# Altium schematic sheet 原理图1.~(1).SchDoc
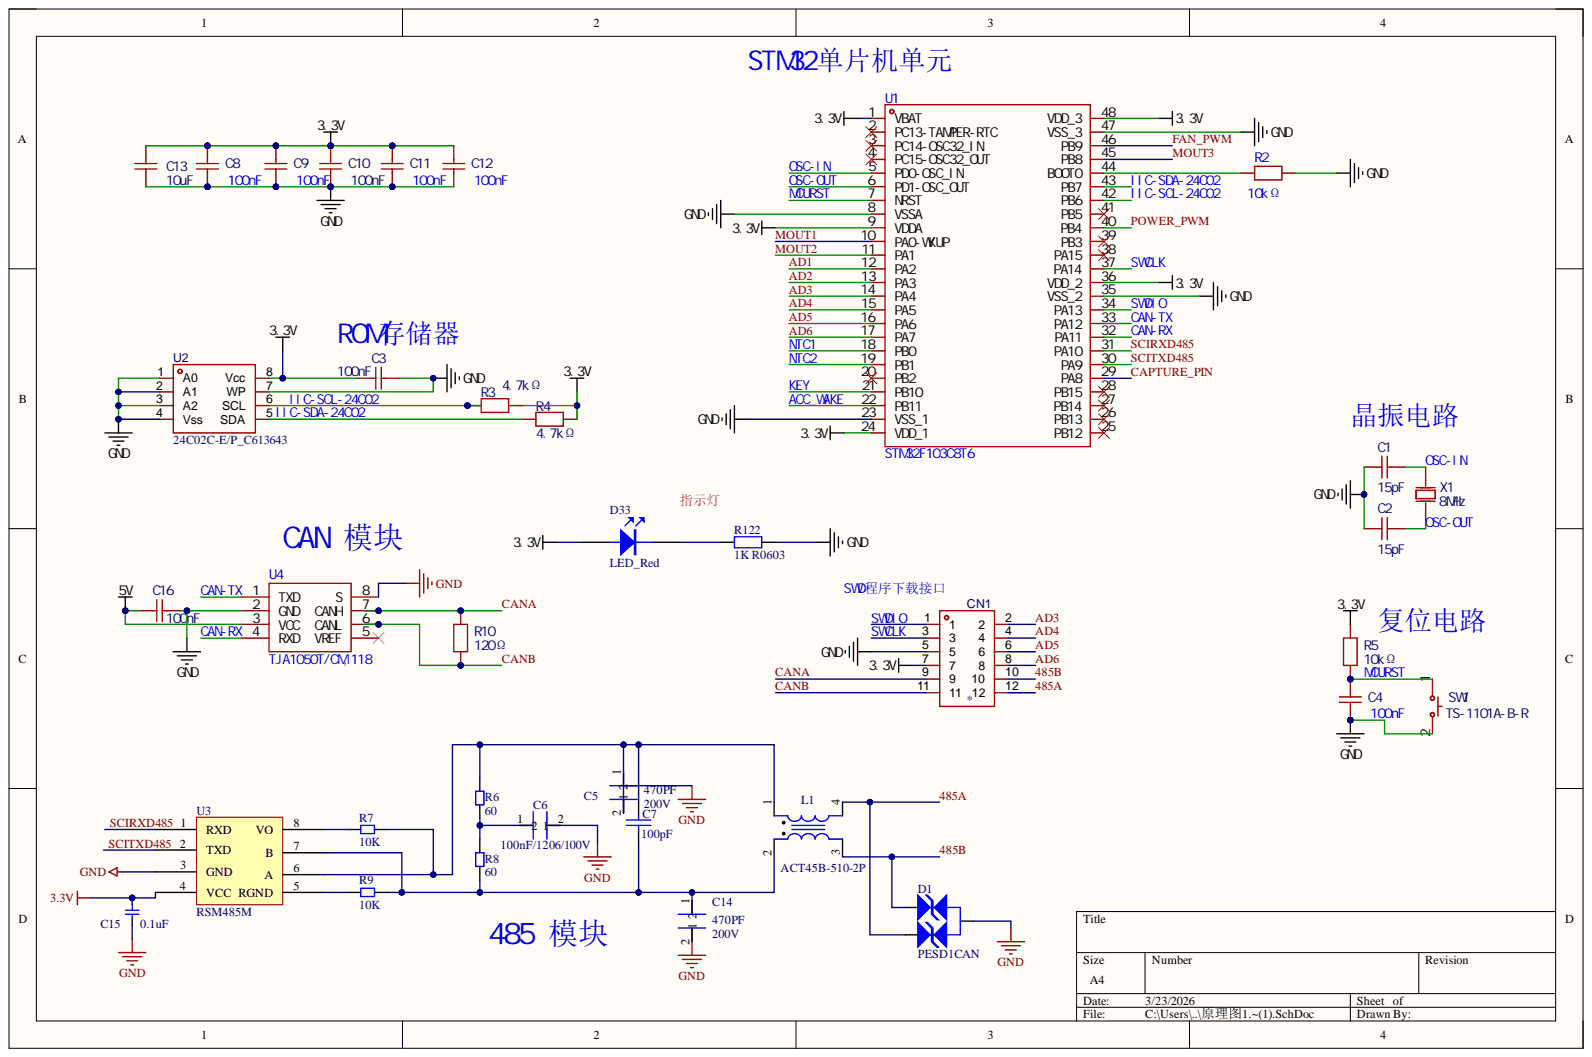
[diagram p0: 原理图1.~(1).SchDoc]

## Parts
C1  15pF  [C0603]
C2  15pF  [C0603]
C3  100nF  [C0603]
C4  100nF  [C0603]
C5  value 470PF  [C1206]  (hidden comment: 470PF, 200V, 5%,)
C6  100nF/1206/100V  [C1206]
C7  100pF  [C0805]
C8  100nF  [C0603]
C9  100nF  [C0603]
C10  100nF  [C0603]
C11  100nF  [C0603]
C12  100nF  [C0603]
C13  10uF  [C0805]
C14  value 470PF  Voltage Rating: 200V  [C1206]  (hidden comment: 470PF, 200V, 5%,)
C15  0.1uF  [C0603]
C16  100nF  [C0603]
CN1  (no value)  [IDC-TH_X9555WV-2X06-PTV01]
D1  PESD1CAN  [SOT-23-D]
D33  LED_Red  [LED0805]
L1  ACT45B-510-2P  [LS-ACT45B-510-2P]
R2  10kΩ  [R0603]
R3  4.7kΩ  [R0603]
R4  4.7kΩ  [R0603]
R5  10kΩ  [R0603]
R6  60  [R0805]
R7  10K  [R0805]
R8  60  [R0805]
R9  10K  [R0805]
R10  120Ω  [R0603]
R122  1K R0603  [R0603]
SW1  TS-1101A-B-R  [SW-SMD_L6.00-W3.60-LS6.0]
U1  STM32F103C8T6  [LQFP-48_L7.0-W7.0-P0.50-LS9.0-BL]
U2  24C02C-E/P_C613643  [PDIP-8_L9.3-W6.4-P2.54-LS7.6-BL]
U3  RSM485M  [RSM485M]
U4  TJA1050T/CM,118  [SOIC-8_L5.0-W4.0-P1.27-LS6.0-BL]
X1  8MHz  [HC-49S_L11.4-W4.8]

## Nets
3.3V: C3.2 C8.2 C9.2 C10.2 C11.2 C12.2 C13.2 C15.1 CN1.7 D33.1 R3.1 R4.1 R5.2 U1.1(VBAT) U1.9(VDDA) U1.24(VDD_1) U1.36(VDD_2) U1.48(VDD_3) U2.8(Vcc) U3.4(VCC)
5V: C16.2 U4.3(VCC)
GND: C1.1 C2.1 C3.1 C4.1 C5.2 C6.2 C8.1 C9.1 C10.1 C11.1 C12.1 C13.1 C14.2 C15.2 C16.1 CN1.5 D1.3 R2.2 R122.2 SW1.2 U1.8(VSSA) U1.23(VSS_1) U1.35(VSS_2) U1.47(VSS_3) U2.1(A0) U2.2(A1) U2.3(A2) U2.4(Vss) U2.7(WP) U3.3(GND) U4.2(GND) U4.8(S)
485A: CN1.12 D1.2 L1.4
485B: CN1.10 D1.1 L1.3
ACC_WAKE: U1.22(PB11)
AD1: U1.12(PA2)
AD2: U1.13(PA3)
AD3: CN1.2 U1.14(PA4)
AD4: CN1.4 U1.15(PA5)
AD5: CN1.6 U1.16(PA6)
AD6: CN1.8 U1.17(PA7)
CAN-RX: U1.32(PA11) U4.4(RXD)
CAN-TX: U1.33(PA12) U4.1(TXD)
CANA: CN1.9 R10.2 U4.7(CANH)
CANB: CN1.11 R10.1 U4.6(CANL)
CAPTURE_PIN: U1.29(PA8)
FAN_PWM: U1.46(PB9)
IIC-SCL-24C02: R3.2 U1.42(PB6) U2.6(SCL)
IIC-SDA-24C02: R4.2 U1.43(PB7) U2.5(SDA)
KEY: U1.21(PB10)
MCURST: C4.2 R5.1 SW1.1 U1.7(NRST)
MOUT1: U1.10(PA0-WKUP)
MOUT2: U1.11(PA1)
MOUT3: U1.45(PB8)
NTC1: U1.18(PB0)
NTC2: U1.19(PB1)
OSC-IN: C1.2 U1.5(PD0-OSC_IN) X1.1
OSC-OUT: C2.2 U1.6(PD1-OSC_OUT) X1.2
POWER_PWM: U1.40(PB4)
SCIRXD485: U1.31(PA10) U3.1(RXD)
SCITXD485: U1.30(PA9) U3.2(TXD)
SWCLK: CN1.3 U1.37(PA14)
SWDIO: CN1.1 U1.34(PA13)
NetC5_1: C5.1 C7.1 L1.1 R6.2 R7.1 U3.6(A)
NetC6_1: C6.1 R6.1 R8.2
NetC7_2: C7.2 C14.1 L1.2 R8.1 R9.1 U3.7(B)
NetD33_2: D33.2 R122.1
NetR2_1: R2.1 U1.44(BOOT0)
NetR7_2: R7.2 U3.8(VO)
NetR9_2: R9.2 U3.5(RGND)

Not connected: U1 2(PC13-TAMPER-RTC) 3(PC14-OSC32_IN) 4(PC15-OSC32_OUT) 20(PB2) 25(PB12) 26(PB13) 27(PB14) 28(PB15) 38(PA15) 39(PB3) 41(PB5); U4 5(VREF)

## Library data of the parts
C1 C2 = GRM21BR60J107ME15L: Manufacturer: muRata(村田); Add into BOM: yes; Temperature Coefficient: X5R; Tolerance: ±20%; Voltage Rated: 6.3V; Component Kind: Standard; Convert to PCB: yes; Datasheet: https://atta.szlcsc.com/upload/public/pdf/source/20171113/C141660_15105613320671115822.pdf; Device: GRM21BR60J107ME15L; JLCPCB Part Class: 扩展库; LCSC Part Name: 100uF ±20% 6.3V; Manufacturer Part: GRM21BR60J107ME15L; PCB3D: C0805_L2.0-W1.3-H1.3; Supplier: LCSC; Supplier Footprint: 0805; Supplier Part: C141660; Symbol: GRM21BR60J107ME15L; Value: 15pF
C3 C4 C8-C12 C16 = CL21B104KBCNNNC: Manufacturer: SAMSUNG(三星); Add into BOM: yes; Temperature Coefficient: X7R; Tolerance: ±10%; Voltage Rated: 50V; Component Kind: Standard; Convert to PCB: yes; Datasheet: https://atta.szlcsc.com/upload/public/pdf/source/20160103/1457707693305.pdf; Device: CL21B104KBCNNNC; JLCPCB Part Class: 扩展库; LCSC Part Name: 100nF ±10% 50V; Manufacturer Part: CL21B104KBCNNNC; PCB3D: C0805_L2.0-W1.3-H1.3; Supplier: LCSC; Supplier Footprint: 0805; Supplier Part: C1711; Symbol: CL21B104KBCNNNC; Value: 100nF
C5 C14 = 085029: CAPACITOR, CERAMIC, COG, 470PF, 200V, 5%, 1206, SMT; Manufacturer: KEMET; Manufacturer #1: VALERE AVL; Part Number: C0805C471J2GACTU; PCB DECAL: 0805MIL; SMD/THD: SMD; Temperature Rating: -55 to +125C; Component Kind: Standard; LAST UPDATED: 20010905; PP#: 085029; Revision: 1 PROTO; Tolerance: 5%
  C5: Voltage Rating: 200V
C6 = 072368: Manufacturer: PANASONIC; Part Number: ECK-TFC222MF; PCB DECAL: CAP-ECK-FC; Value: 2200pF; Voltage Rating: 250V; Component Kind: Standard; Description: 100nF/1206/100V; PP#: 072368
C7 = 0805_C: Component Kind: Standard; Library Name: Dsp28335_Controller.SchLib
C13 = CL31A106KBHNNNE: Manufacturer: SAMSUNG(三星); Add into BOM: yes; Temperature Coefficient: X5R; Tolerance: ±10%; Voltage Rated: 50V; Component Kind: Standard; Convert to PCB: yes; Datasheet: https://atta.szlcsc.com/upload/public/pdf/source/20160103/1457707668742.pdf; Device: CL31A106KBHNNNE; JLCPCB Part Class: 基础库; LCSC Part Name: 10uF ±10% 50V; Manufacturer Part: CL31A106KBHNNNE; PCB3D: C1206_L3.2-W1.6-H1.3; Supplier: LCSC; Supplier Footprint: 1206; Supplier Part: C13585; Symbol: CL31A106KBHNNNE; Value: 10uF
C15 = 0603_C: Component Kind: Standard; Library Name: Dsp28335_Controller.SchLib
CN1 = X9555WV-2X06-PTV01: Component Kind: Standard; Symbol: X9555WV-2X06-PTV01
D33 = LED_2: Component Kind: Standard; Library Name: Schlib1.SchLib
L1 = CM_choke: Component Kind: Standard; Library Name: Dsp28335_Controller.SchLib
R2-R5 R10 = ERA6ARB104V: Manufacturer: PANASONIC(松下); Add into BOM: yes; Overload Voltage (Max): 100V; Power(Watts): 125mW; Temperature Coefficient: ±10ppm/K; Tolerance: ±0.1%; Component Kind: Standard; Convert to PCB: yes; Datasheet: https://atta.szlcsc.com/upload/public/pdf/source/20200407/C509089_CAF233FEBB67060CAB00AD625F0D5E9C.pdf; Device: ERA6ARB104V; JLCPCB Part Class: 扩展库; LCSC Part Name: 100KΩ ±0.1% 1/8W; Manufacturer Part: ERA6ARB104V; Operating Temperature Range: -55℃~+155℃; PCB3D: R0805_L2.0-W1.3-H0.6; Supplier: LCSC; Supplier Footprint: 0805; Supplier Part: C509089; Symbol: ERA6ARB104V; Type: 薄膜电阻
  R2 R5: Value: 10kΩ
  R3 R4: Value: 4.7kΩ
  R10: Value: 120Ω
R6 R8 = 0805_R: 60/R0805; Component Kind: Standard; Library Name: Dsp28335_Controller.SchLib
R7 R9 = 0805_R: 10K/R0805; Component Kind: Standard; Library Name: Dsp28335_Controller.SchLib
R122 = Res2: Component Kind: Standard; LatestRevisionDate: 17-Jul-2002; LatestRevisionNote: Re-released for DXP Platform.; Library Name: stm32原理图库.SCHLIB; Published: 8-Jun-2000; Signal Integrity: Res; Simulation: RESISTOR; Publisher: Altium Limited
SW1 = TS-1101A-B-R: Manufacturer: XKB Connectivity(中国星坤); Actuator Style: 矩形按钮; Actuator/Cap Color: 棕色; Add into BOM: yes; Circuit: 单刀单掷; Contact Current: 50mA; Insulation Resistance: 100mΩ; Mechanical Life: 100000; Operating Force: 180gf; Strike Gundam: 否; Switch Height: 2.5mm; Switch Length: 6mm; Switch Width: 3.6mm; Voltage Rating (DC): 12V; With Lamp: 无; Component Kind: Standard; Convert to PCB: yes; Datasheet: https://atta.szlcsc.com/upload/public/pdf/source/20211015/FB820AB85B64273C1A496D9A04A54222.pdf; Device: TS-1101A-B-R; JLCPCB Part Class: 扩展库; LCSC Part Name: 6*3.6*2.5翘脚 160gf 红色按钮; Manufacturer Part: TS-1101A-B-R; Mounting Style: 立贴; Operating Temperature: -30℃~+85℃; PCB3D: SW-SMD_L6.00-W3.60-H2.5-LS6.0; Supplier: LCSC; Supplier Footprint: SMD; Supplier Part: C388637; Symbol: TS-1101A-B-R
U1 = STM32F103C8T6: Manufacturer: ST(意法半导体); ADC (Bit): 12bit; Add into BOM: yes; CPU Core: ARM Cortex-M3; CPU Maximum Speed: 72MHz; EEPROM: 64KB; GPIO Ports Number: 37; Internal Oscillator: 有; Operating Voltage Range: 2V~3.6V; Peripheral/Function: 看门狗；DMA；看门狗；片载温度传感器; Program Memory Type: FLASH; RAM Size: 20KB; CCP Capture/Compare: -; communication protocol: -; Component Kind: Standard; Convert to PCB: yes; DAC (Bit): -; Datasheet: https://atta.szlcsc.com/upload/public/pdf/source/20220812/8363ACEC51AD55ECEB84799464F7CB85.pdf; Device: STM32F103C8T6; Direct Memory Access: -; Infrared Data Association: -; Internal Comparator: -; JLCPCB Part Class: 基础库; LCSC Part Name: ARM Cortex-M3 72MHz MSL=3; Library Name: Schlib1.SchLib; Low-Voltage Detect: -; Manufacturer Part: STM32F103C8T6; Operating Temperature Range: -40℃~+85℃; PCB3D: LQFP-48_L7.0-W7.0-H1.4-LS9.0-P0.50; Program Storage Size: 64KB; PWM (Bit): -; Real-Time Clock: -; Secure Digital Input and Output: -; Supplier: LCSC; Supplier Footprint: LQFP-48(7x7); Supplier Part: C8734; Symbol: STM32F103C8T6; Universal Serial Bus: -; Watchdog: -
U4 = TJA1050T/CM,118: Manufacturer: NXP(恩智浦); Add into BOM: yes; Supply Voltage: 4.75V~5.25V; Component Kind: Standard; Convert to PCB: yes; Datasheet: https://atta.szlcsc.com/upload/public/pdf/source/20140411/1457707042917.pdf; Device: TJA1050T/CM,118; JLCPCB Part Class: 基础库; LCSC Part Name: TJA1050T/CM,118; Manufacturer Part: TJA1050T/CM,118; PCB3D: SOIC-8_L4.9-W3.9-H1.7-LS6.0-P1.27; Supplier: LCSC; Supplier Footprint: SOIC-8-150mil; Supplier Part: C6952; Symbol: TJA1050T/CM,118; Type: 收发器
X1 = X49SM8MSB2SC: Manufacturer: YXC(扬兴晶振); Add into BOM: yes; Crystal Type: 49SMD; Frequency Stability: ±30ppm; Frequency Tolerance: ±10ppm; Load Capacitance: 20pF; Component Kind: Standard; Convert to PCB: yes; Datasheet: https://atta.szlcsc.com/upload/public/pdf/source/20210329/C106809_0E91E60850A780B284B63D653DC40EA4.pdf; Device: X49SM8MSB2SC; JLCPCB Part Class: 扩展库; LCSC Part Name: HC-49SMD 8MHz ±10ppm 20pF; Manufacturer Part: X49SM8MSB2SC; Operating Temperature: -20℃~+70℃; PCB3D: HC-49S_L11.4-W1.8-H3.0-LS12.7; Supplier: LCSC; Supplier Footprint: HC-49S-SMD-2P; Supplier Part: C108703; Symbol: X49SM8MSB2SC; Value: 8MHz
D1 = PESD1CAN: Component Kind: Standard
U2 = 24C02C-E/P_C613643: Component Kind: Standard; Library Name: Schlib1.SchLib; Symbol: 24C02C-E/P_C613643
U3 = RSM485M: Component Kind: Standard; Library Name: Dsp28335_Controller.SchLib
